# Altium schematic sheet [12] - USB 3.0 DRP II.SchDoc("[12] - USB 3.0 DRP II")
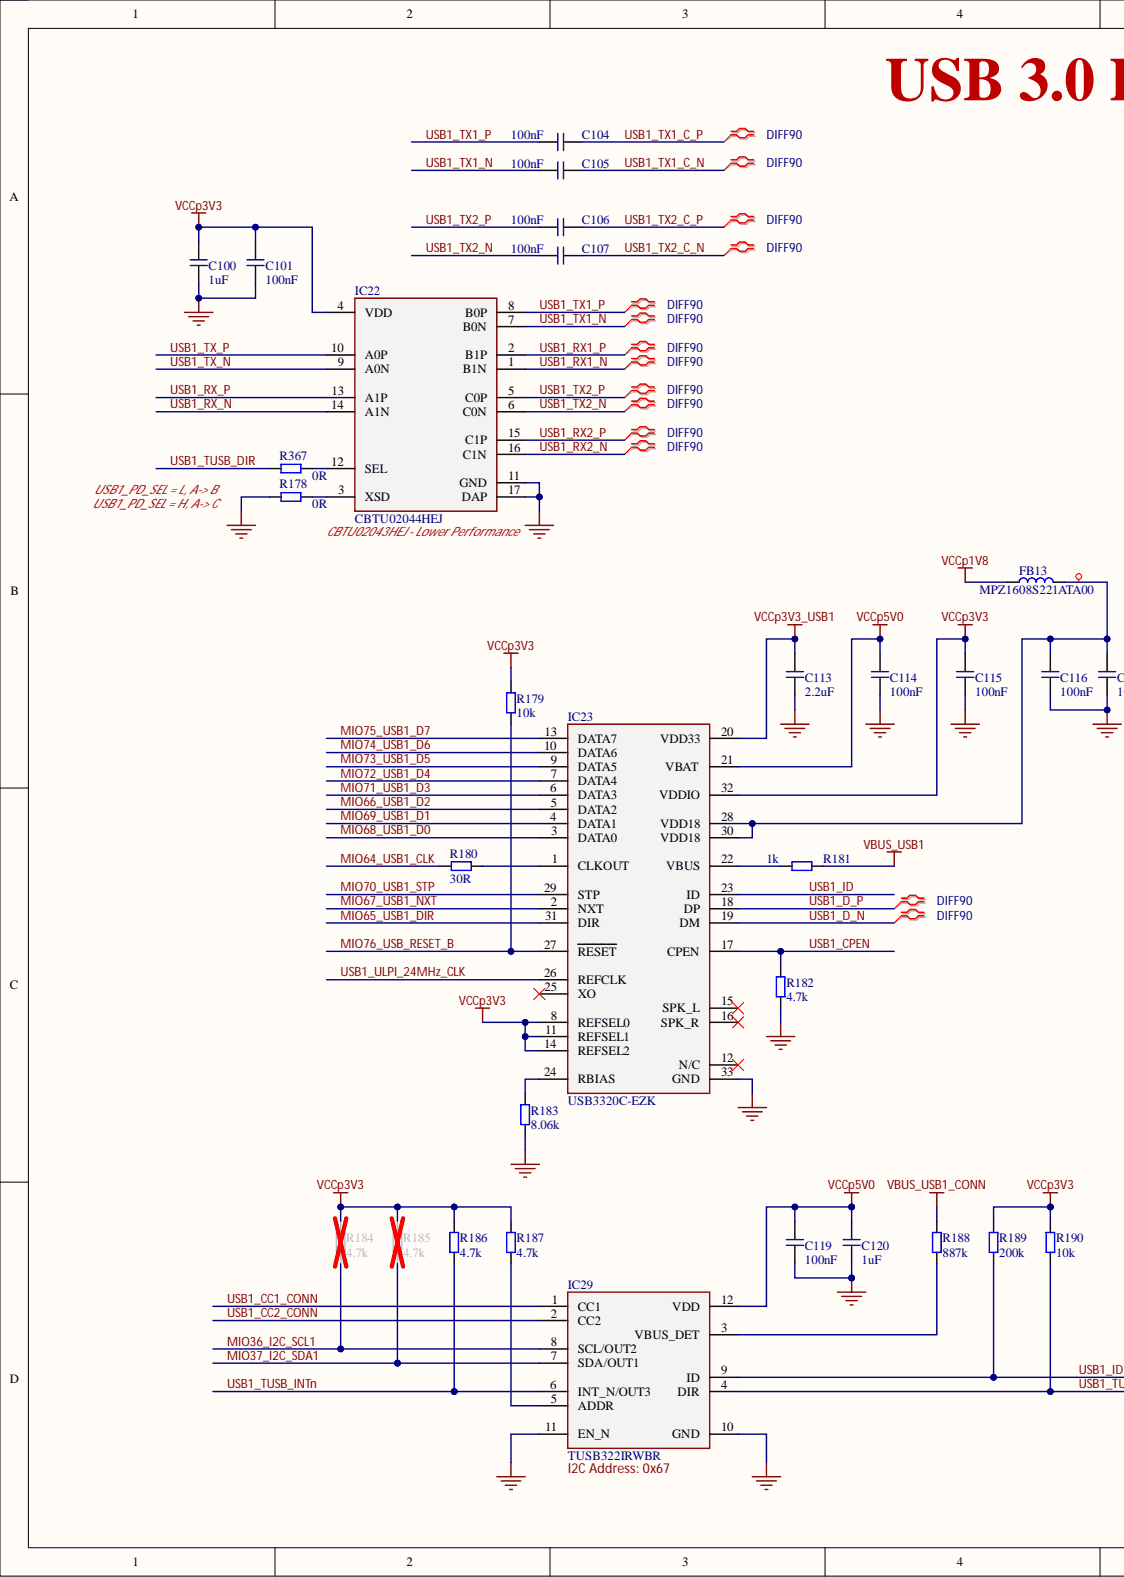
[diagram p0: [12] - USB 3.0 DRP II.SchDoc, left]
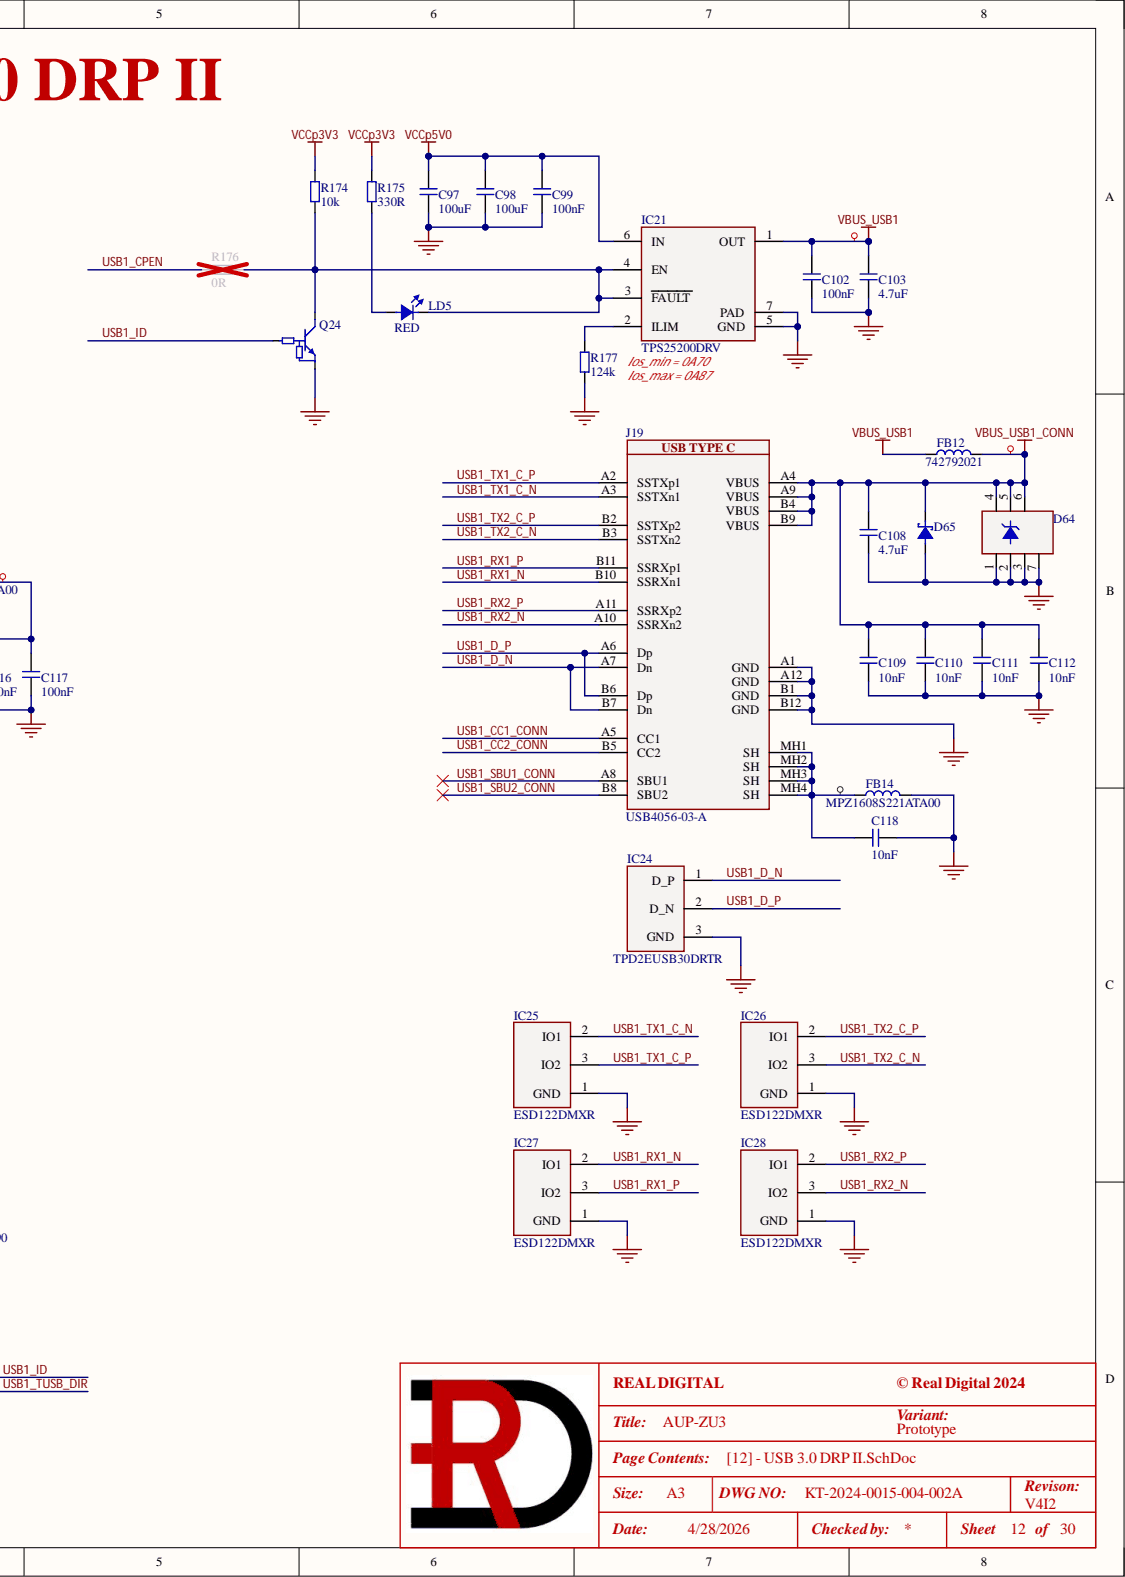
[diagram p1: [12] - USB 3.0 DRP II.SchDoc, right]

## Parts
C97  100uF  [C1210]
C98  100uF  [C1210]
C99  100nF  [C0402]
C100  1uF  [C0603]
C101  100nF  [C0201]
C102  100nF  [C0402]
C103  4.7uF  [C0603]
C104  100nF  [C0201]
C105  100nF  [C0201]
C106  100nF  [C0201]
C107  100nF  [C0201]
C108  4.7uF  [C0603]
C109  10nF  [C0402]
C110  10nF  [C0402]
C111  10nF  [C0402]
C112  10nF  [C0402]
C113  2.2uF  [C0603]
C114  100nF  [C0201]
C115  100nF  [C0201]
C116  100nF  [C0201]
C117  100nF  [C0201]
C118  10nF  [C0402]
C119  100nF  [C0201]
C120  1uF  [C0402]
D64  TVS0500DRVR  [DRV0006A_WSON_6 - TI]  (value not drawn)
D65  NSR20F30NXT5G  [DSN2 - ONSEMI]  (value not drawn)
FB12  742792021  [BLM21]
FB13  MPZ1608S221ATA00  [MPZ1608]
FB14  MPZ1608S221ATA00  [MPZ1608]
IC21  TPS25200DRV  [DRV0006A_WSON_6 - TI]
IC22  CBTU02044HEJ  [SOT1832-1_HUQFN16 - NXP]
IC23  USB3320C-EZK  [QFN32_5x5mm - Microchip]
IC24  TPD2EUSB30DRTR  [DRT0003A - TI]
IC25  ESD122DMXR  [DMX0003A - TI]
IC26  ESD122DMXR  [DMX0003A - TI]
IC27  ESD122DMXR  [DMX0003A - TI]
IC28  ESD122DMXR  [DMX0003A - TI]
IC29  TUSB322IRWBR  [RWB0012A - TI]
J19  USB4056-03-A  [USB4056-03-A - GCT]
LD5  RED  [LD0603_RED]
Q24  DTC043ZEBTL  [SC89-EMT3F]  (value not drawn)
R174  10k  [R0402]
R175  330R  [R0402]
R176  0R  [R0402]
R177  124k  [R0402]
R178  0R  [R0402]
R179  10k  [R0402]
R180  30R  [R0201]
R181  1k  [R0402]
R182  4.7k  [R0402]
R183  8.06k  [R0402]
R184  4.7k  [R0402]
R185  4.7k  [R0402]
R186  4.7k  [R0402]
R187  4.7k  [R0402]
R188  887k  [R0402]
R189  200k  [R0402]
R190  10k  [R0402]
R367  0R  [R0402]

## Nets
GND: C97.1 C98.1 C99.1 C100.1 C101.1 C102.1 C103.1 C108.1 C109.1 C110.1 C111.1 C112.1 C113.1 C114.1 C115.1 C116.1 C117.1 C118.2 C119.1 C120.1 D64.1 D64.2 D64.3 D64.7 D65.A FB14.2 IC21.5(GND) IC21.7(PAD) IC22.11(GND) IC22.17(DAP) IC23.33(GND) IC24.3 IC25.1 IC26.1 IC27.1 IC28.1 IC29.10(GND) IC29.11(EN_N) J19.A1(GND) J19.A12(GND) J19.B1(GND) J19.B12(GND) Q24.GND R177.1 R178.2 R182.1 R183.1
VBUS_USB1: C102.2 C103.2 FB12.1 IC21.1(OUT) R181.2
VBUS_USB1_CONN: C108.2 C109.2 C110.2 C111.2 C112.2 D64.4 D64.5 D64.6 D65.K FB12.2 J19.A4(VBUS) J19.A9(VBUS) J19.B4(VBUS) J19.B9(VBUS) R188.2
VCCp1V8: FB13.1
VCCp3V3: C100.2 C101.2 C115.2 IC22.4(VDD) IC23.8(REFSEL0) IC23.11(REFSEL1) IC23.14(REFSEL2) IC23.32(VDDIO) R174.2 R175.2 R179.2 R184.2 R185.2 R186.2 R187.2 R189.2 R190.2
VCCp3V3_USB1: C113.2 IC23.20(VDD33)
VCCp5V0: C97.2 C98.2 C99.2 C114.2 C119.2 C120.2 IC21.6(IN) IC23.21(VBAT) IC29.12(VDD)
MIO36_I2C_SCL1: IC29.8(SCL/OUT2) R184.1
MIO37_I2C_SDA1: IC29.7(SDA/OUT1) R185.1
MIO64_USB1_CLK: R180.2
MIO65_USB1_DIR: IC23.31(DIR)
MIO66_USB1_D2: IC23.5(DATA2)
MIO67_USB1_NXT: IC23.2(NXT)
MIO68_USB1_D0: IC23.3(DATA0)
MIO69_USB1_D1: IC23.4(DATA1)
MIO70_USB1_STP: IC23.29(STP)
MIO71_USB1_D3: IC23.6(DATA3)
MIO72_USB1_D4: IC23.7(DATA4)
MIO73_USB1_D5: IC23.9(DATA5)
MIO74_USB1_D6: IC23.10(DATA6)
MIO75_USB1_D7: IC23.13(DATA7)
MIO76_USB_RESET_B: IC23.27(RESET) R179.1
USB1_CC1_CONN: IC29.1(CC1) J19.A5(CC1)
USB1_CC2_CONN: IC29.2(CC2) J19.B5(CC2)
USB1_CPEN: IC23.17(CPEN) R176.1 R182.2
USB1_D_N: IC23.19(DM) IC24.1 J19.A7(Dn) J19.B7(Dn)
USB1_D_P: IC23.18(DP) IC24.2 J19.A6(Dp) J19.B6(Dp)
USB1_ID: IC23.23(ID) IC29.9(ID) Q24.IN R189.1
USB1_RX1_N: IC22.1(B1N) IC27.2 J19.B10(SSRXn1)
USB1_RX1_P: IC22.2(B1P) IC27.3 J19.B11(SSRXp1)
USB1_RX2_N: IC22.16(C1N) IC28.3 J19.A10(SSRXn2)
USB1_RX2_P: IC22.15(C1P) IC28.2 J19.A11(SSRXp2)
USB1_RX_N: IC22.14(A1N)
USB1_RX_P: IC22.13(A1P)
USB1_SBU1_CONN: J19.A8(SBU1)
USB1_SBU2_CONN: J19.B8(SBU2)
USB1_TUSB_DIR: IC29.4(DIR) R190.1 R367.2
USB1_TUSB_INTn: IC29.6(INT_N/OUT3) R186.1
USB1_TX1_C_N: C105.2 IC25.2 J19.A3(SSTXn1)
USB1_TX1_C_P: C104.2 IC25.3 J19.A2(SSTXp1)
USB1_TX1_N: C105.1 IC22.7(B0N)
USB1_TX1_P: C104.1 IC22.8(B0P)
USB1_TX2_C_N: C107.2 IC26.3 J19.B3(SSTXn2)
USB1_TX2_C_P: C106.2 IC26.2 J19.B2(SSTXp2)
USB1_TX2_N: C107.1 IC22.6(C0N)
USB1_TX2_P: C106.1 IC22.5(C0P)
USB1_TX_N: IC22.9(A0N)
USB1_TX_P: IC22.10(A0P)
USB1_ULPI_24MHz_CLK: IC23.26(REFCLK)
NetC116_2: C116.2 C117.2 FB13.2 IC23.28(VDD18) IC23.30(VDD18)
NetC118_1: C118.1 FB14.1 J19.MH1(SH) J19.MH2(SH) J19.MH3(SH) J19.MH4(SH)
NetIC21_2: IC21.2(ILIM) R177.2
NetIC21_3: IC21.3(FAULT) IC21.4(EN) LD5.K Q24.OUT R174.1 R176.2
NetIC22_3: IC22.3(XSD) R178.1
NetIC22_12: IC22.12(SEL) R367.1
NetIC23_1: IC23.1(CLKOUT) R180.1
NetIC23_22: IC23.22(VBUS) R181.1
NetIC23_24: IC23.24(RBIAS) R183.2
NetIC29_3: IC29.3(VBUS_DET) R188.1
NetIC29_5: IC29.5(ADDR) R187.1
NetLD5_A: LD5.A R175.1

Not connected: IC23 12(N/C) 15(SPK_L) 16(SPK_R) 25(XO)

## Library data of the parts
C97 C98 = GRM32ER61A107ME20K: CAP CER 100uF 10V X5R 1210; Manufacturer 1: Murata Electronics; .Checked_PCB: RV; C P/N: RD-111-0112; LoadStatus: Load; Tolerance: 20%; Category: Capacitors; Component Kind: Standard; Library Name: KT-RealDigital.IntLib; Manufacturer 1 Part Number: GRM32ER61A107ME20K; Temperature Coefficient: X5R; Voltage - Rated: 10V; .Checked_SCH: RV; .Creator: RV
C99 C102 = GRM155R71E104KE14J: CAP CER 0.1uF 25V X7R 0402; Manufacturer 1: Murata Electronics; .Checked_PCB: AC; .Creator: RV; C P/N: RD-111-0006; LoadStatus: Load; Tolerance: 10%; Category: Capacitors; Component Kind: Standard; Library Name: KT-RealDigital.IntLib; Manufacturer 1 Part Number: GRM155R71E104KE14J; Temperature Coefficient: X7R; Voltage - Rated: 25V; .Checked_SCH: AC
C100 = GRM188R71A105KA61D: CAP CER 1uF 10V X7R 0603; Manufacturer 1: Murata Electronics; .Checked_PCB: AC; .Creator: RV; C P/N: RD-111-0071; LoadStatus: Load; Tolerance: 10%; Category: Capacitors; Component Kind: Standard; Library Name: KT-RealDigital.IntLib; Manufacturer 1 Part Number: GRM188R71A105KA61D; Temperature Coefficient: X7R; Voltage - Rated: 10V; .Checked_SCH: AC
C101 C104-C107 C114-C117 C119 = GRM033C71C104KE14D: CAP CER 0.1uF 16V X7S 0201; Manufacturer 1: Murata Electronics; .Checked_PCB: RV; C P/N: 111-0800; LoadStatus: Load; Tolerance: 10%; Category: Capacitors; Component Kind: Standard; Library Name: KT-RealDigital.IntLib; Manufacturer 1 Part Number: GRM033C71C104KE14D; Temperature Coefficient: X7S; Voltage - Rated: 16V; .Checked_SCH: RV; .Creator: RV
C103 C108 = GRM188R6YA475KE15D: CAP CER 4.7uF 35V X5R 0603; Manufacturer 1: Murata Electronics; .Checked_PCB: DG; .Creator: RV; C P/N: RD-111-0064; LoadStatus: Load; Tolerance: 10%; Category: Capacitors; Component Kind: Standard; Library Name: KT-RealDigital.IntLib; Manufacturer 1 Part Number: GRM188R6YA475KE15D; Temperature Coefficient: X5R; Voltage - Rated: 35V; .Checked_SCH: DG
C109-C112 C118 = GRM155R71H103KA88J: CAP CER 10000pF 50V X7R 0402; Manufacturer 1: Murata Electronics; .Checked_PCB: AC; .Creator: RV; C P/N: RD-111-0003; LoadStatus: Load; Tolerance: 10%; Category: Capacitors; Component Kind: Standard; Library Name: KT-RealDigital.IntLib; Manufacturer 1 Part Number: GRM155R71H103KA88J; Temperature Coefficient: X7R; Voltage - Rated: 50V; .Checked_SCH: AC
C113 = GRM188R71A225KE15J: CAP CER 2.2uF 10V X7R 0603; Manufacturer 1: Murata Electronics; .Checked_PCB: AC; .Creator: RV; C P/N: RD-111-0023; LoadStatus: Load; Tolerance: 10%; Category: Capacitors; Component Kind: Standard; Library Name: KT-RealDigital.IntLib; Manufacturer 1 Part Number: GRM188R71A225KE15J; Temperature Coefficient: X7R; Voltage - Rated: 10V; .Checked_SCH: AC
C120 = GRM155Z71A105KE01J: CAP CER 1uF 10V X7R 0402; Manufacturer 1: Murata Electronics; .Checked_PCB: RV; C P/N: RD-111-0058; LoadStatus: Load; Tolerance: 10%; Category: Capacitors; Component Kind: Standard; Library Name: KT-RealDigital.IntLib; Manufacturer 1 Part Number: GRM155Z71A105KE01J; Temperature Coefficient: X7R; Voltage - Rated: 10V; .Checked_SCH: RV; .Creator: RV
D64 = TVS0500DRVR: 5V flat-clamp surge protection device; Manufacturer 1: Texas Instruments; .Checked_PCB: DG; .Creator: RV; C P/N: RD-121-0528; LoadStatus: Load; Category: TVS - Diodes; Component Kind: Standard; Library Name: KT-RealDigital.IntLib; Manufacturer 1 Part Number: TVS0500DRVR; .Checked_SCH: DG
D65 = NSR20F30NXT5G: DIODE SCHOTTKY 30V 2A 2DSN; Manufacturer 1: onsemi; .Checked_PCB: RV; C P/N: RD-121-0014; LoadStatus: Load; Category: Diodes; Component Kind: Standard; Library Name: KT-RealDigital.IntLib; Manufacturer 1 Part Number: NSR20F30NXT5G; .Checked_SCH: RV; .Creator: RV
FB12 = 742792021: FERRITE BEAD 22 OHM 0805 1LN; Manufacturer 1: Würth Elektronik; .Checked_PCB: DG; .Creator: RV; C P/N: RD-131-0023; LoadStatus: Load; Category: Ferrite Beads; Component Kind: Standard; Library Name: KT-RealDigital.IntLib; Manufacturer 1 Part Number: 742792021; .Checked_SCH: DG
FB13 FB14 = MPZ1608S221ATA00: FERRITE BEAD 220 OHM 0603 1LN; Manufacturer 1: TDK Corporation; .Checked_PCB: AC; .Checked_SCH: RV; C P/N: CY-121-0068; LoadStatus: Load; Category: Ferrite Beads; Component Kind: Standard; Library Name: KT-RealDigital.IntLib; Manufacturer 1 Part Number: MPZ1608S221ATA00; .Creator: RV
IC21 = TPS25200DRV: IC PWR MGR EFUSE 6.5V 2.8A 6SON; Manufacturer 1: Texas Instruments; .Checked_PCB: RV; C P/N: RD-126-0094; LoadStatus: Load; Category: IC; Component Kind: Standard; Library Name: KT-RealDigital.IntLib; Manufacturer 1 Part Number: TPS25200DRV; .Checked_SCH: RV; .Creator: RV
IC22 = CBTU02044HEJ: SWITCH 1-2 PCIE GEN4 HUQFN16; Manufacturer 1: NXP USA Inc.; .Checked_PCB: DG; .Creator: RV; C P/N: RD-126-0100; LoadStatus: Load; Category: IC; Component Kind: Standard; Library Name: KT-RealDigital.IntLib; Manufacturer 1 Part Number: CBTU02044HEJ; .Checked_SCH: DG
IC23 = USB3320C-EZK: IC TRANSCEIVER HALF 1/1 32QFN; Manufacturer 1: Microchip Technology; .Checked_PCB: RV; C P/N: 126-1133; LoadStatus: Load; Category: IC; Component Kind: Standard; Library Name: KT-RealDigital.IntLib; Manufacturer 1 Part Number: USB3320C-EZK; .Checked_SCH: RV; .Creator: RV
IC24 = TPD2EUSB30DRTR: TVS DIODE 5.5V 8V SOT3; Manufacturer 1: Texas Instruments; .Checked_PCB: RV; C P/N: 121-0524; LoadStatus: Load; Category: TVS - Diodes; Component Kind: Standard; Library Name: KT-RealDigital.IntLib; Manufacturer 1 Part Number: TPD2EUSB30DRTR; .Checked_SCH: RV; .Creator: RV
IC25-IC28 = ESD122DMXR: TVS DIODE 3.6VWM 8.4VC 3X2SON; Manufacturer 1: Texas Instruments; .Checked_PCB: DG; .Creator: RV; C P/N: 121-0550; LoadStatus: Load; Category: TVS - Diodes; Component Kind: Standard; Library Name: KT-RealDigital.IntLib; Manufacturer 1 Part Number: ESD122DMXR; .Checked_SCH: DG
IC29 = TUSB322IRWBR: IC INTERFACE SPECIALIZED 12X2QFN; Manufacturer 1: Texas Instruments; .Checked_PCB: RV; C P/N: 126-1503; LoadStatus: Load; Category: IC; Component Kind: Standard; Library Name: KT-RealDigital.IntLib; Manufacturer 1 Part Number: TUSB322IRWBR; .Checked_SCH: RV; .Creator: RV
J19 = USB4056-03-A: USB C 3.2 REC, HORZ, HYBRID PCB; Manufacturer 1: GCT; .Checked_PCB: RV; C P/N: EP-163-0073; LoadStatus: Load; Category: Connectors; Component Kind: Standard; Library Name: KT-RealDigital.IntLib; Manufacturer 1 Part Number: USB4056-03-A; .Checked_SCH: RV; .Creator: RV
LD5 = LED_RED: LED RED 0603; Manufacturer 1: EVERLIGHT ELECTRONICS CO.,LTD.; Manufacturer 1 Part Number: 19-21SURC/S530-XX/TR8; .Checked_PCB: AC; .Creator: RV; C P/N: 121-0001; LoadStatus: Load; Category: Led; Component Kind: Standard; Library Name: KT-RealDigital.IntLib; .Checked_SCH: AC
Q24 = DTC043ZEBTL: TRANS PREBIAS NPN 50V EMT3F; Manufacturer 1: Rohm Semiconductor; .Checked_PCB: RV; C P/N: RD-121-0006; LoadStatus: Load; Category: BJTs; Component Kind: Standard; Library Name: KT-RealDigital.IntLib; Manufacturer 1 Part Number: DTC043ZEBTL; .Checked_SCH: RV; .Creator: RV
R174 = RT0402BRB0710KL: RES SMD 10K OHM 0.1% 1/16W 0402; Manufacturer 1: YAGEO; .Checked_PCB: AC; .Creator: RV; C P/N: 101-0862; LoadStatus: Load; Tolerance: 0.1%/TK10; Category: Resistors; Component Kind: Standard; Library Name: KT-RealDigital.IntLib; Manufacturer 1 Part Number: RT0402BRB0710KL; .Checked_SCH: AC
R175 = RC0402JR-07330RL: RES SMD 330 OHM 5% 1/16W 0402; Manufacturer 1: Yageo; .Checked_PCB: AC; .Creator: RV; C P/N: 101-0112; LoadStatus: Load; Tolerance: 5%/TK100; Category: Resistors; Component Kind: Standard; Library Name: KT-RealDigital.IntLib; Manufacturer 1 Part Number: RC0402JR-07330RL; .Checked_SCH: AC
R176 R178 R367 = RC0402FR-070RL: RES SMD 0 OHM JUMPER 1/16W 0402; Manufacturer 1: YAGEO; .Checked_PCB: AC; .Creator: RV; C P/N: RD-101-0003; LoadStatus: Load; Category: Resistors; Component Kind: Standard; Library Name: KT-RealDigital.IntLib; Manufacturer 1 Part Number: RC0402FR-070RL; Tolerance: Jumper; .Checked_SCH: AC
R177 = RT0402BRD07124KL: RES SMD 124K OHM 0.1% 1/16W 0402; Manufacturer 1: Yageo; .Checked_PCB: RV; C P/N: RD-101-0062; LoadStatus: Load; Tolerance: 0.1%/TK25; Category: Resistors; Component Kind: Standard; Library Name: KT-RealDigital.IntLib; Manufacturer 1 Part Number: RT0402BRD07124KL; .Checked_SCH: RV; .Creator: RV
R179 = RC0402JR-0710KL: RES SMD 10K OHM 5% 1/16W 0402; Manufacturer 1: Yageo; .Checked_PCB: AC; .Creator: RV; C P/N: 101-0047; LoadStatus: Load; Tolerance: 5%/TK100; Category: Resistors; Component Kind: Standard; Library Name: KT-RealDigital.IntLib; Manufacturer 1 Part Number: RC0402JR-0710KL; .Checked_SCH: AC
R180 = RC0201FR-0730RL: RES SMD 30 OHM 1% 1/20W 0201; Manufacturer 1: YAGEO; .Checked_PCB: RV; C P/N: RD-101-0009; LoadStatus: Load; Tolerance: 1%/TK200; Category: Resistors; Component Kind: Standard; Library Name: KT-RealDigital.IntLib; Manufacturer 1 Part Number: RC0201FR-0730RL; .Checked_SCH: RV; .Creator: RV
R181 = RC0402FR-071KL: RES SMD 1K OHM 1% 1/16W 0402; Manufacturer 1: Yageo; .Checked_PCB: RV; C P/N: RD-101-0010; LoadStatus: Load; Tolerance: 1%/TK100; Category: Resistors; Component Kind: Standard; Library Name: KT-RealDigital.IntLib; Manufacturer 1 Part Number: RC0402FR-071KL; .Checked_SCH: RV; .Creator: RV
R182 R184-R187 = RC0402FR-074K7P: RES SMD 4.7K OHM 1% 1/16W 0402; Manufacturer 1: Yageo; .Checked_PCB: AC; .Creator: RV; C P/N: 101-0129; LoadStatus: Load; Tolerance: 1%/TK100; Category: Resistors; Component Kind: Standard; Library Name: KT-RealDigital.IntLib; Manufacturer 1 Part Number: RC0402FR-074K7P; .Checked_SCH: AC
R183 = RC0402FR-078K06L: RES SMD 8.06K OHM 1% 1/16W 0402; Manufacturer 1: Yageo; .Checked_PCB: RV; C P/N: 101-0506; LoadStatus: Load; Tolerance: 1%/TK100; Category: Resistors; Component Kind: Standard; Library Name: KT-RealDigital.IntLib; Manufacturer 1 Part Number: RC0402FR-078K06L; .Checked_SCH: RV; .Creator: RV
R188 = RC0402FR-07887KL: RES 887K OHM 1% 1/16W 0402; Manufacturer 1: YAGEO; .Checked_PCB: RV; C P/N: 101-0090; LoadStatus: Load; Tolerance: 1%/TK100; Category: Resistors; Component Kind: Standard; Library Name: KT-RealDigital.IntLib; Manufacturer 1 Part Number: RC0402FR-07887KL; .Checked_SCH: RV; .Creator: RV
R189 = RC0402FR-07200KL: RES SMD 200K OHM 1% 1/16W 0402; Manufacturer 1: Yageo; .Checked_PCB: RV; C P/N: CY-101-0332; LoadStatus: Load; Tolerance: 1%/TK100; Category: Resistors; Component Kind: Standard; Library Name: KT-RealDigital.IntLib; Manufacturer 1 Part Number: RC0402FR-07200KL; .Checked_SCH: RV; .Creator: RV
R190 = RC0402FR-0710KL: RES SMD 10K OHM 1% 1/16W 0402; Manufacturer 1: Yageo; .Checked_PCB: AC; .Creator: RV; C P/N: RD-101-0020; LoadStatus: Load; Tolerance: 1%/TK100; Category: Resistors; Component Kind: Standard; Library Name: KT-RealDigital.IntLib; Manufacturer 1 Part Number: RC0402FR-0710KL; .Checked_SCH: AC
